# Altium schematic sheet CON-USB.SchDoc(M)
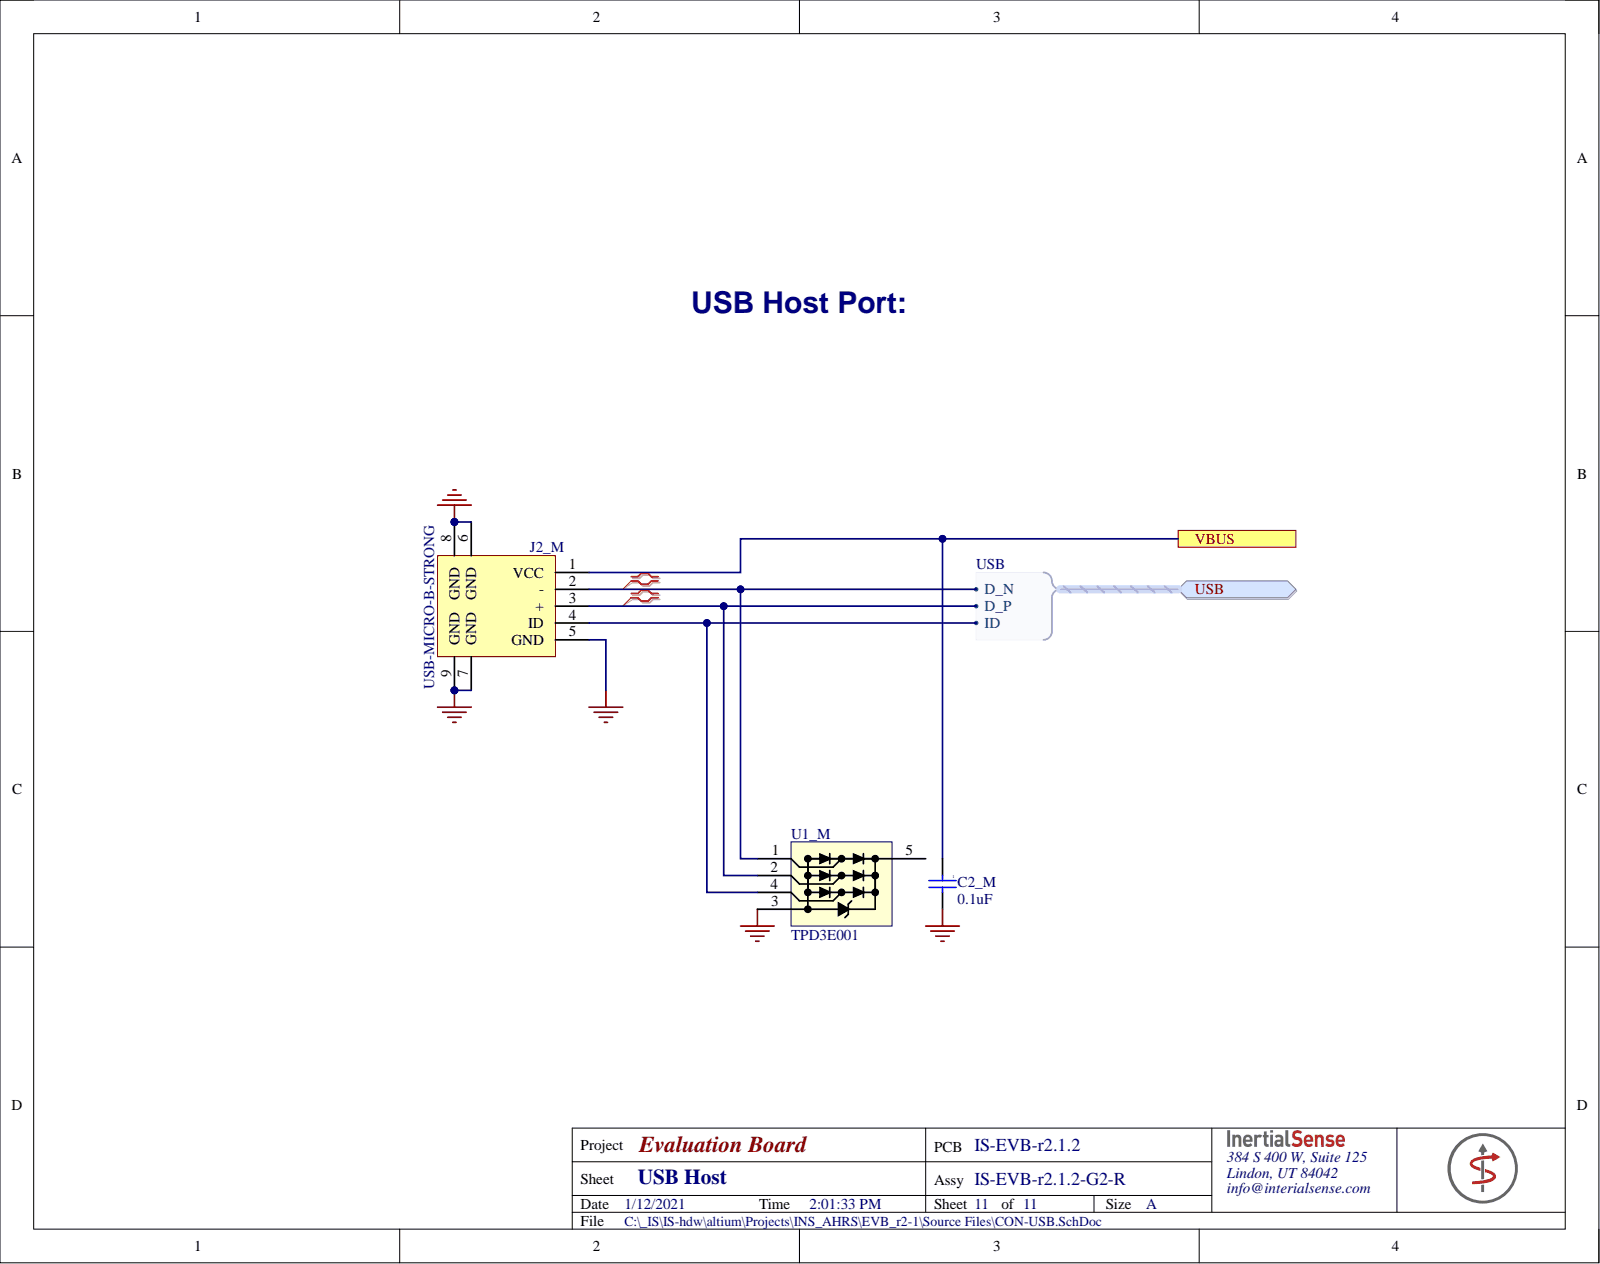
[diagram p0: CON-USB.SchDoc]

## Parts
C2_M  value 0.1uF  [0402]  (hidden comment: Cap)
J2_M  USB-MICRO-B-STRONG  [USB Micro AB R/A THR - design]
U1_M  TPD3E001  [SOTFL50P160X60-5N]

## Ports
USB.D_N_M, USB.D_P_M, USB.ID_M, USB_M, VBUS_M

## Nets
GND: C2_M.2 J2_M.5(GND) J2_M.6(GND) J2_M.7(GND) J2_M.8(GND) J2_M.9(GND) U1_M.3
NetC2_M_1: C2_M.1 J2_M.1(VCC) ; port VBUS_M
NetJ2_M_2: J2_M.2(-) U1_M.1 ; port USB_M
NetJ2_M_3: J2_M.3(+) U1_M.2 ; port USB_M
NetJ2_M_4: J2_M.4(ID) U1_M.4 ; port USB_M
NetU1_M_5: U1_M.5

## Library data of the parts
C2_M = Cap: CAP CER .10UF 50V X7R 10% 0402; Manufacturer: TDK Corporation; Manufacturer Part Number: C1005X7R1H104K; Capacitance: 0.1µF; Applications: General Purpose; Category: Capacitors; Component Kind: Standard; ComponentLink1Description: http://www.tdk.co.jp/tefe02/e412_c.pdf; ComponentLink1URL: http://www.tdk.co.jp/tefe02/e412_c.pdf; Features: -; Height: -; LatestRevisionDate: 17-Jul-2002; LatestRevisionNote: Re-released for DXP Platform.; Lead Spacing: -; Lead Style: -; Library Name: General.SchLib; Mounting Type: Surface Mount, MLCC; Operating Temperature: -55°C ~ 125°C; Package / Case: 0402 (1005 Metric); Packaging: Cut Tape (CT); Pricing 1: 1=0.3, 10=0.2, 100=0.08, 250=0.05752, 500=0.041, 1000=0.03 (USD); Published: 8-Jun-2000; Ratings: -; RoHS: RoHS Compliant; Signal Integrity: Cap; Simulation: CAP; Size / Dimension: 0.039" L x 0.020" W (1.00mm x 0.50mm); Stock 1: 174080; Supplier 1: Digi-Key; Supplier Part Number 1: 445-5932-1-ND; Temperature Coefficient: X7R; Thickness: 0.022" (0.55mm); Tolerance: ±10%; Voltage - Rated: 50V; Publisher: Altium Limited
J2_M = USB-TYPEAB-4GND: CONN USB MICRO B RECPT SMT R/A; Manufacturer: Amphenol FCI; Manufacturer Part Number: 10118194-0001LF; Connector Type: USB,Receptacle; Current Rating: 1.8A; Gender: Receptacle; Insulation Resistance: 1GR; Max Voltage Rating (AC): 100V; Number of Contacts: 5; Number of Ports: 1; Pitch: 0.65mm; Colour: Black; Component Kind: Standard; ComponentLink1Description: https://datasheet.ciiva.com/851/10118194-851110.pdf; ComponentLink1URL: https://datasheet.ciiva.com/851/10118194-851110.pdf; ComponentLink2Description: https://datasheet.ciiva.com/851/10118194-851111.pdf; ComponentLink2URL: https://datasheet.ciiva.com/851/10118194-851111.pdf; ComponentLink3Description: https://datasheet.ciiva.com/4598/10118194ac-197217-4598807.pdf; ComponentLink3URL: https://datasheet.ciiva.com/4598/10118194ac-197217-4598807.pdf; ComponentLink4Description: https://datasheet.ciiva.com/29907/10118194-29907230.pdf; ComponentLink4URL: https://datasheet.ciiva.com/29907/10118194-29907230.pdf; ComponentLink5Description: https://datasheet.ciiva.com/26945/getdatasheetpartid-418619-26945695.pdf; ComponentLink5URL: https://datasheet.ciiva.com/26945/getdatasheetpartid-418619-26945695.pdf; Contact Material: Copper Alloy; Contact Plating: Gold; Depth: 5mm; Features: Shielded; Flammability Rating: UL94 V-0; Housing Material: Polymer; Lead Free: Lead Free; Length: 8.05mm; Library Name: Connectors.SchLib; Max Operating Temperature: 85°C; Min Operating Temperature: -55°C; Mount: Surface Mount,Horizontal; Number of Terminals: 5; Orientation: Right Angle; Package Quantity: 9600; Packaging: Tape and Reel; Pricing 1: 1=0.5, 10=0.464, 25=0.418, 50=0.3716, 100=0.3561, 250=0.32516, 500=0.30966 (USD); Radiation Hardening: No; Stock 1: 178809; Supplier 1: Digi-Key; Supplier Part Number 1: 609-4618-6-ND; Termination: Solder
U1_M = TPD3E001: TVS DIODE 5.5V SOT5; Manufacturer: Texas Instruments; Manufacturer Part Number: TPD3E001DRLR; Breakdown Voltage: 11V; Capacitance: 1.5pF; Clamping Voltage: 105.5V; Direction: Unidirectional; Interface: USB; Leakage Current: 1nA; Max Supply Current: 100nA; Min Supply Voltage: 900mV; Number of Bidirectional Channels: 3; Peak Pulse Power: 90W; Power Line Protection: Yes; Case/Package: SOT; Component Kind: Standard; Depth: 1.2mm; Height: 0.55mm; Lead Free: Lead Free; Length: 1.6mm; Library Name: ICs.SchLib; Max Operating Temperature: 85°C; Max Supply Voltage: 5.5V; Max Supply Voltage (DC): 5.5V; Min Breakdown Voltage: 11V; Min Operating Temperature: -40°C; Min Supply Voltage (DC): 900mV; Nominal Supply Current: 1nA; Number of Channels: 3; Number of Pins: 5; Package Quantity: 1; Packaging: Tape and Reel; Pricing 1: 1=0.53, 10=0.454, 100=0.3392, 500=0.26648, 1000=0.20592 (USD); Radiation Hardening: No; REACH SVHC: No SVHC; Reverse Standoff Voltage: 5.5V; Stock 1: 81393; Supplier 1: Digi-Key; Supplier Part Number 1: 296-21885-1-ND; Termination: SMD/SMT; Weight: 9.9E-05oz; Width: 1.2mm
